# Altium schematic sheet activity_tracker.SchDoc(activity_tracker)
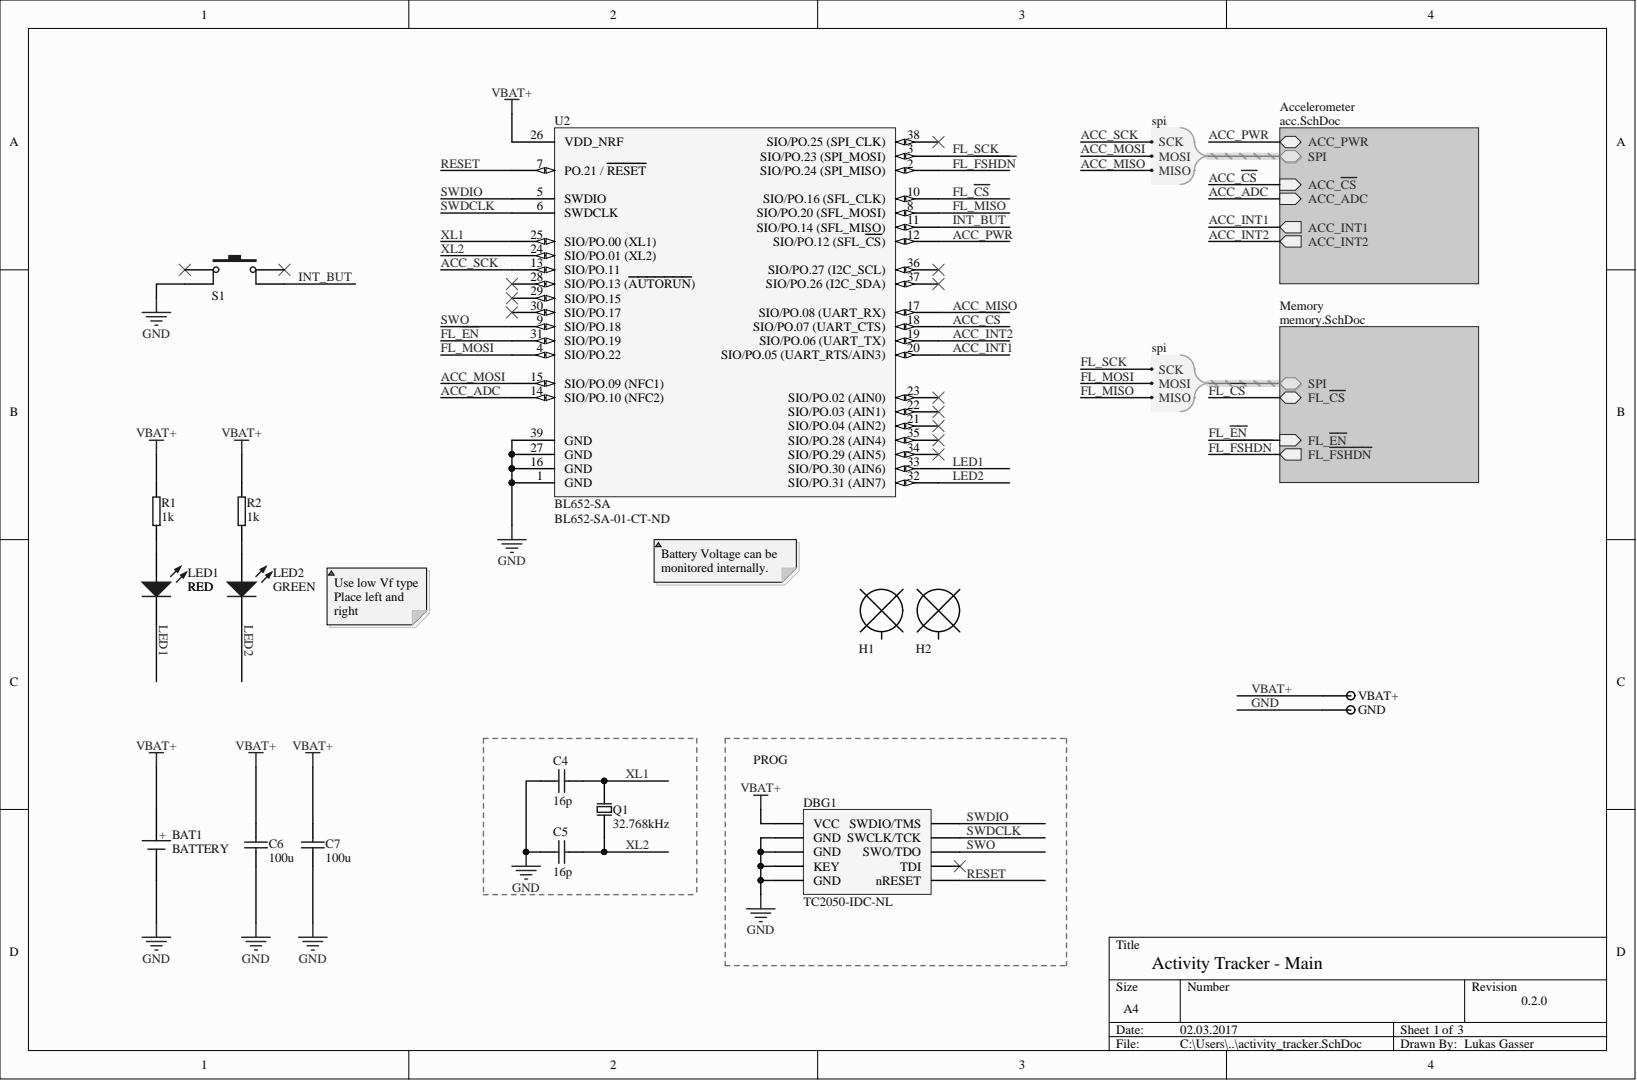
[diagram p0: activity_tracker.SchDoc]

## Parts
BAT1  BATTERY  [BK-912_MIN_FP]
C4  16p  [CAPC1608X95N]
C5  16p  [CAPC1608X95N]
C6  100u  [CAPC3216X18N]
C7  100u  [CAPC3216X18N]
DBG1  TC2050-IDC-NL  [TC2050-IDC-NL]
GND  Testpoint  [POGO1]  (value not drawn)  (no BOM)
H1  HOLE  [M1_SMALL_NOKEEPOUT]  (value not drawn)  (no BOM)
H2  HOLE  [M1_SMALL_NOKEEPOUT]  (value not drawn)  (no BOM)
LED1  RED  [LED0603]
LED2  GREEN  [LED0603]
Q1  32.768kHz  [ABS06]
R1  1k  [RESC1608X55N]
R2  1k  [RESC1608X55N]
S1  SW-PB-4T  [TL3315NF160Q]  (value not drawn)
U2  BL652-SA  Supplier Part Number 1: BL652-SA-01-CT-ND  [BL652-SA_NO_KEEPOUT]
VBAT+  Testpoint  [POGO1]  (value not drawn)  (no BOM)

## Nets
ACC_ADC: U2.14(SIO/PO.10_(NFC2))
ACC_~{CS}: U2.18(SIO/PO.07_(UART_CTS))
ACC_INT1: U2.20(SIO/PO.05_(UART_RTS/AIN3))
ACC_INT2: U2.19(SIO/PO.06_(UART_TX))
ACC_MISO: U2.17(SIO/PO.08_(UART_RX))
ACC_MOSI: U2.15(SIO/PO.09_(NFC1))
ACC_PWR: U2.12(SIO/PO.12_(SFL_CS))
ACC_SCK: U2.13(SIO/PO.11)
FL_~{CS}: U2.10(SIO/PO.16_(SFL_CLK))
FL_~{EN}: U2.31(SIO/PO.19)
FL_~{FSHDN}: U2.2(SIO/PO.24_(SPI_MISO))
FL_MISO: U2.8(SIO/PO.20_(SFL_MOSI))
FL_MOSI: U2.4(SIO/PO.22)
FL_SCK: U2.3(SIO/PO.23_(SPI_MOSI))
GND: BAT1.- C4.1 C5.1 C6.1 C7.1 DBG1.3(GND) DBG1.5(GND) DBG1.7(KEY) DBG1.9(GND) GND.1 S1.2 U2.1(GND) U2.16(GND) U2.27(GND) U2.39(GND)
INT_BUT: S1.4 U2.11(SIO/PO.14_(SFL_MISO))
LED1: LED1.2 U2.33(SIO/PO.30_(AIN6))
LED2: LED2.2 U2.32(SIO/PO.31_(AIN7))
RESET: DBG1.10(nRESET) U2.7(PO.21_/_RESET)
SWDCLK: DBG1.4(SWCLK/TCK) U2.6(SWDCLK)
SWDIO: DBG1.2(SWDIO/TMS) U2.5(SWDIO)
SWO: DBG1.6(SWO/TDO) U2.9(SIO/PO.18)
VBAT+: BAT1.+ C6.2 C7.2 DBG1.1(VCC) R1.2 R2.2 U2.26(VDD_NRF) VBAT+.1
XL1: C4.2 Q1.2 U2.25(SIO/PO.00_(XL1))
XL2: C5.2 Q1.1 U2.24(SIO/PO.01_(XL2))
NetDBG1_8: DBG1.8(TDI)
NetH1_H: H1.H
NetH2_H: H2.H
NetLED1_1: LED1.1 R1.1
NetLED2_1: LED2.1 R2.1
NetS1_1: S1.1
NetS1_3: S1.3
NetU2_21: U2.21(SIO/PO.04_(AIN2))
NetU2_22: U2.22(SIO/PO.03_(AIN1))
NetU2_23: U2.23(SIO/PO.02_(AIN0))
NetU2_28: U2.28(SIO/PO.13_(AUTORUN))
NetU2_29: U2.29(SIO/PO.15)
NetU2_30: U2.30(SIO/PO.17)
NetU2_34: U2.34(SIO/PO.29_(AIN5))
NetU2_35: U2.35(SIO/PO.28_(AIN4))
NetU2_36: U2.36(SIO/PO.27_(I2C_SCL))
NetU2_37: U2.37(SIO/PO.26_(I2C_SDA))
NetU2_38: U2.38(SIO/PO.25_(SPI_CLK))

## Library data of the parts
C4 C5 = C: Capacitor; Voltage: 50V; Value: 16p; Supplier Part Number 1: 1276-2204-1-ND; Supplier 1: Digi-Key; Library Name: rlc.IntLib; Component Kind: Standard; ClassName: ignoreSilkscreen
C6 C7 = C: Capacitor; Voltage: 6V3; Value: 100u; Supplier Part Number 1: 490-10525-1-ND; Supplier 1: Digi-Key; Library Name: rlc.IntLib; Component Kind: Standard
DBG1 = TC2050-IDC-NL: ARM Mini JTAG / SWD 10-pin connector; Supplier Part Number 1: TC2050-IDC-NL-ND; Supplier 1: Digi-Key; Component Kind: Standard; Library Name: icsp_debug-connectors.IntLib
LED1 LED2 = LED: Supplier 1: Digi-Key; Library Name: led.IntLib; Description: LED; Component Kind: Standard
  LED1: Supplier Part Number 1: 754-1541-1-ND; Color: RED
  LED2: Supplier Part Number 1: 160-1478-1-ND
Q1 = Q-0Shield: Crystal; Supplier Part Number 1: 535-10247-1-ND; Supplier 1: Digi-Key; Library Name: crystal.IntLib; Frequency: 32.768kHz; Component Kind: Standard; ClassName: directConnect,ignoreSilkscreen
R1 R2 = R: Resistor; Value: 1k; Supplier Part Number 1: 1276-5062-1-ND; Supplier 1: Digi-Key; Library Name: rlc.IntLib; Component Kind: Standard
S1 = SW-PB-4T: Tact switch; Supplier Part Number 1: EG4621CT-ND; Supplier 1: Digi-Key; Library Name: switch.IntLib; Component Kind: Standard; ClassName: directConnect
U2 = BL652-SA: RF TXRX MOD BLUETOOTH INT ANT; Supplier 1: Digi-Key; Library Name: bluetooth.IntLib; Component Kind: Standard
BAT1 = BATTERY: Supplier Part Number 1: BK-912-G-CT-ND; Supplier 1: Digi-Key; Library Name: battery.IntLib; Description: Battery; Component Kind: Standard; ClassName: directConnect
GND VBAT+ = Testpoint: Library Name: connector.IntLib; Description: Testpoint; ClassName: directConnect
H1 H2 = HOLE: Library Name: misc.IntLib; Description: Hole; ClassName: directConnect
